# Altium schematic sheet Jetson_power.SchDoc
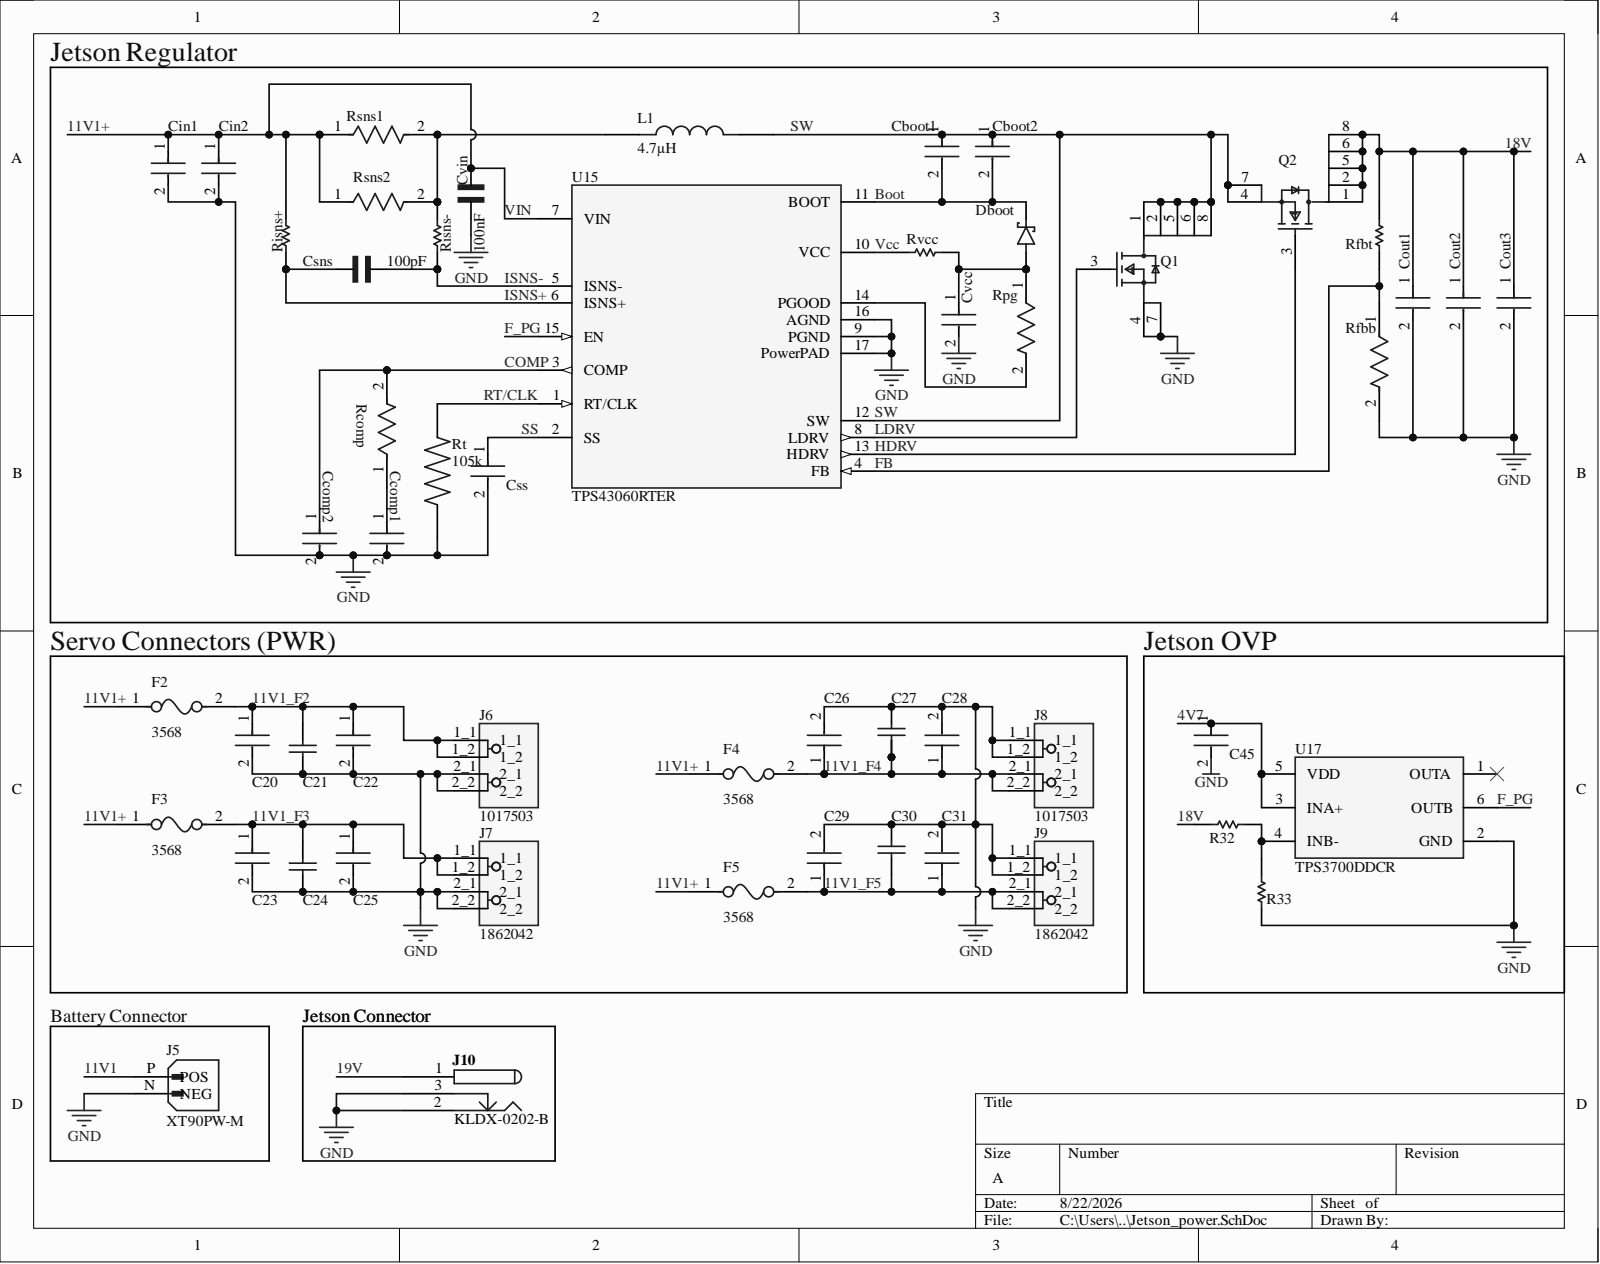
[diagram p0: Jetson_power.SchDoc]

## Parts
C20  CL32A106KBJNNNE  [FP-CL32-IPC_B]  (value not drawn)
C21  C0805C105K5RACTU  [CAPC2013X140X50ML20T25]  (value not drawn)
C22  CC0805KRX7R9BB104  [FP-CC0805-0_85-MFG]  (value not drawn)
C23  CL32A106KBJNNNE  [FP-CL32-IPC_B]  (value not drawn)
C24  C0805C105K5RACTU  [CAPC2013X140X50ML20T25]  (value not drawn)
C25  CC0805KRX7R9BB104  [FP-CC0805-0_85-MFG]  (value not drawn)
C26  CL32A106KBJNNNE  [FP-CL32-IPC_B]  (value not drawn)
C27  C0805C105K5RACTU  [CAPC2013X140X50ML20T25]  (value not drawn)
C28  CC0805KRX7R9BB104  [FP-CC0805-0_85-MFG]  (value not drawn)
C29  CL32A106KBJNNNE  [FP-CL32-IPC_B]  (value not drawn)
C30  C0805C105K5RACTU  [CAPC2013X140X50ML20T25]  (value not drawn)
C31  CC0805KRX7R9BB104  [FP-CC0805-0_85-MFG]  (value not drawn)
C45  GRM155R71H104KE14D  [FP-GRM155-0_05-IPC_B]  (value not drawn)
Cboot1  CC0805KRX7R9BB104  [FP-CC0805-0_85-MFG]  (value not drawn)
Cboot2  CC0805KRX7R9BB104  [FP-CC0805-0_85-MFG]  (value not drawn)
Ccomp1  CGA4C2C0G1H822J060AA  [FP-CGA4J-IPC_A]  (value not drawn)
Ccomp2  GRM1555C1H391JA01J  [FP-GRM155-0_05-IPC_A]  (value not drawn)
Cin1  CL32A106KBJNNNE  [FP-CL32-IPC_B]  (value not drawn)
Cin2  CL32A106KBJNNNE  [FP-CL32-IPC_B]  (value not drawn)
Cout1  CL32B106KBJNNWE  [FP-CL32-IPC_B]  (value not drawn)
Cout2  CL32B106KBJNNWE  [FP-CL32-IPC_B]  (value not drawn)
Cout3  CL32B106KBJNNWE  [FP-CL32-IPC_B]  (value not drawn)
Csns  value 100pF  [CAPC1608X90N]  (hidden comment: GRM1885C1H101JA01D)
Css  GRM155R71H104KE14D  [FP-GRM155-0_05-IPC_B]  (value not drawn)
Cvcc  GRM188R61C106MA73D  [FP-GRM188-0_2-IPC_A]  (value not drawn)
Cvin  value 100nF  [CAPC1005X55N]  (hidden comment: GRM155R71C104KA88D)
Dboot  XBS053V15R-G  [DIO_XBS053V15R-G]  (value not drawn)
F2  3568  [FP-3568-MFG]
F3  3568  [FP-3568-MFG]
F4  3568  [FP-3568-MFG]
F5  3568  [FP-3568-MFG]
J5  XT90PW-M  [AMASS_XT90PW-M - duplicate]
J6  1017503  [PHOENIX_1017503]
J7  1862042  [PHOENIX_1862042]
J8  1017503  [PHOENIX_1017503]
J9  1862042  [PHOENIX_1862042]
J10  KLDX-0202-B  [KYCN-KLDX-0202-B_V]
L1  value 4.7µH  [IND_XAL6060-472MEB]  (hidden comment: XAL6060-472MEB)
Q1  CSD17571Q2  [FP-DQK0006C-MFG]  (value not drawn)
Q2  CSD17571Q2  [FP-DQK0006C-MFG]  (value not drawn)
R32  CRCW0402475KFKED  [RESC1005X40X25LL05T05]  (value not drawn)
R33  CRCW040210K0FKEDHP  [RESC1005X40X25ML05T10]  (value not drawn)
Rcomp  CRCW04025K36FKED  [FP-CRCW0402-e3-IPC_B]  (value not drawn)
Rfbb  CRCW040211K0FKED  [FP-CRCW0402-e3-IPC_A]  (value not drawn)
Rfbt  CRCW0402150KFKED  [RESC1005X40X25NL05T05]  (value not drawn)
Risns+  CRCW040210R0FKED  [RESC1005X40X25NL05T05]  (value not drawn)
Risns-  CRCW040210R0FKED  [RESC1005X40X25NL05T05]  (value not drawn)
Rpg  CRCW0402100KFKED  [FP-CRCW0402-e3-IPC_C]  (value not drawn)
Rsns1  PRL1632-R010-F-T1  [FP-PRL1632-MFG]  (value not drawn)
Rsns2  PRL1632-R010-F-T1  [FP-PRL1632-MFG]  (value not drawn)
Rt  value 105k  [RESC0603X26N]  (hidden comment: RC0201FR-07105KL)
Rvcc  CRCW04022R00FKED  [RESC1005X40X25ML05T05]  (value not drawn)
U15  TPS43060RTER  [FP-RTE0016E-IPC_B]
U17  TPS3700DDCR  [FP-DDC0006A-IPC_A]

## Nets
GND: C20.2 C21.1 C22.2 C23.2 C24.1 C25.2 C26.2 C27.1 C28.2 C29.2 C30.1 C31.2 C45.2 Ccomp1.2 Ccomp2.2 Cin1.2 Cin2.2 Cout1.2 Cout2.2 Cout3.2 Css.2 Cvcc.2 Cvin.1 J5.N J6.2_1 J6.2_2 J7.2_1 J7.2_2 J8.1_1 J8.1_2 J9.1_1 J9.1_2 J10.2 J10.3 Q1.4 Q1.7 R33.2 Rfbb.2 Rt.2 U15.9(PGND) U15.16(AGND) U15.17(PowerPAD) U17.2(GND)
4V7: C45.1 U17.3(INA+) U17.5(VDD)
11V1: J5.P
11V1+: Cin1.1 Cin2.1 Cvin.2 F2.1 F3.1 F4.1 F5.1 Risns+.1 Rsns1.1 Rsns2.1 U15.7(VIN)
11V1_F2: C20.1 C21.2 C22.1 F2.2 J6.1_1 J6.1_2
11V1_F3: C23.1 C24.2 C25.1 F3.2 J7.1_1 J7.1_2
11V1_F4: C26.1 C27.2 C28.1 F4.2 J8.2_1 J8.2_2
11V1_F5: C29.1 C30.2 C31.1 F5.2 J9.2_1 J9.2_2
18V: Cout1.1 Cout2.1 Cout3.1 Q2.1 Q2.2 Q2.5 Q2.6 Q2.8 R32.1 Rfbt.2
19V: J10.1
Boot: Cboot1.2 Cboot2.2 Dboot.C U15.11(BOOT)
COMP: Ccomp2.1 Rcomp.2 U15.3(COMP)
F_PG: U15.15(EN) U17.6(OUTB)
FB: Rfbb.1 Rfbt.1 U15.4(FB)
HDRV: Q2.3 U15.13(HDRV)
ISNS+: Csns.1 Risns+.2 U15.6(ISNS+)
ISNS-: Csns.2 Risns-.1 U15.5(ISNS-)
LDRV: Q1.3 U15.8(LDRV)
RT/CLK: Rt.1 U15.1(RT/CLK)
SS: Css.1 U15.2(SS)
SW: Cboot1.1 Cboot2.1 L1.2 Q1.1 Q1.2 Q1.5 Q1.6 Q1.8 Q2.4 Q2.7 U15.12(SW)
Vcc: Rvcc.1 U15.10(VCC)
NetCcomp1_1: Ccomp1.1 Rcomp.1
NetCvcc_1: Cvcc.1 Dboot.A Rpg.1 Rvcc.2
NetL1_1: L1.1 Risns-.2 Rsns1.2 Rsns2.2
NetR32_2: R32.2 R33.1 U17.4(INB-)
NetRpg_2: Rpg.2 U15.14(PGOOD)

Not connected: U17 1(OUTA)

## Library data of the parts
C20 C23 C26 C29 Cin1 Cin2 = CMP-13271-001337-1: Cap Ceramic 10uF 50V X5R ±10% SMD 1210 +85°C Embossed T/R; Capacitance: 10uF; Case Code (Imperial): 1210; Case Code (Metric): 3225; Case/Package: 1210; Component Kind: Standard; Depth: 2.5mm; Dielectric: X5R; Lead Free: Lead Free; Length: 0.126inch; Library Name: Altium Content Vault; Max Operating Temperature: 85°C; Min Operating Temperature: -55°C; Mount: Surface Mount; Packaging: Tape and Reel; Thickness: 0.106inch; Tolerance: 10%; Voltage Rating: 50V; Voltage Rating (DC): 50V; Width: 0.098inch
C21 C24 C27 C30 = CMP-2007-03236-1: Manufacturer: Kemet; Component Kind: Standard; Library Name: Altium Content Vault; Part Number: C0805C105K5RACTU
C22 C25 C28 C31 = CMP-2100-03606-3: CAP CER 0.1UF 50V X7R 0805; Manufacturer: Yageo; Capacitance: 100 nF; Material: Ceramic; Tolerance: 0.1; Value: 100 nF; Automotive: No; Category: Cap; Component Kind: Standard; ComponentLink2Description: Datasheet; ComponentLink2URL: http://www.yageo.com/documents/recent/UPY-GPHC_Y5V_6.3V-to-50V_8.pdf; Device Class L1: Passive Components; Device Class L2: Capacitors; Device Class L3: Ceramic Capacitors; Digikey Description: CAP CER 0.1UF 50V X7R 0805; Footprint Url: http://www.yageo.com/exep/pages/download/literatures/UPY-C_GEN_24.pdf; Height: 0.95mm; Ipc Land Pattern Name: CAPC200125X85; Lead Free: Yes; Library Name: Altium Content Vault; Manufacturer Part Number: CC0805KRX7R9BB104; Mouser Description: Multilayer Ceramic Capacitors MLCC - SMD/SMT 100nF 50V X7R 10%; Octopart: https://octopart.com/cc0805krx7r9bb104-yageo-192993; Package: CC0805-0.85; RoHS: TRUE; Temperature: -30°C to +85°C; Temperature Characteristic: X7R; Temperature Range High: +85°C; Temperature Range Low: -30°C; Voltage Rating: 50 V
C45 Css = CMP-2008-04639-2: Chip Multilayer Ceramic Capacitors for General Purpose, 0402, 0.10uF, X7R, 15%, 10%, 50V; Capacitance: 100nF; Dissipation Factor: 0.025%; Case Code (Imperial): 0402; Case Code (Metric): 1005; Case/Package: 0402; Component Kind: Standard; Depth: 0.5mm; Dielectric: X7R; Dielectric Material: Ceramic; Height: 0.5mm; Lead Free: Lead Free; Length: 1mm; Library Name: Altium Content Vault; Material: Ceramic; Max Operating Temperature: 125°C; Min Operating Temperature: -55°C; Mount: Surface Mount; Package Quantity: 10000; Packaging: Tape and Reel; REACH SVHC: No SVHC; Resistor Type: Ceramic; Termination: SMD/SMT; Thickness: 0.022inch; Tolerance: 10%; Voltage: 50V; Voltage Rating: 50V; Voltage Rating (DC): 50V; Weight: 0.000212oz; Width: 0.5mm
Cboot1 Cboot2 = CMP-2100-03606-3: CAP CER 0.1UF 50V X7R 0805; Manufacturer: Yageo; Capacitance: 100 nF; Tolerance: 0.1; Value: 100 nF; Automotive: No; Category: Cap; Component Kind: Standard; ComponentLink2Description: Datasheet; ComponentLink2URL: http://www.yageo.com/documents/recent/UPY-GPHC_Y5V_6.3V-to-50V_8.pdf; Device Class L1: Passive Components; Device Class L2: Capacitors; Device Class L3: Ceramic Capacitors; Digikey Description: CAP CER 0.1UF 50V X7R 0805; Footprint Url: http://www.yageo.com/exep/pages/download/literatures/UPY-C_GEN_24.pdf; Height: 0.95mm; Ipc Land Pattern Name: CAPC200125X85; Lead Free: Yes; Library Name: Altium Content Vault; Manufacturer Part Number: CC0805KRX7R9BB104; Material: Ceramic; Mouser Description: Multilayer Ceramic Capacitors MLCC - SMD/SMT 100nF 50V X7R 10%; Octopart: https://octopart.com/cc0805krx7r9bb104-yageo-192993; Package: CC0805-0.85; RoHS: TRUE; Temperature: -30°C to +85°C; Temperature Characteristic: X7R; Temperature Range High: +85°C; Temperature Range Low: -30°C; Voltage Rating: 50 V
Ccomp1 = CMP-08246-000542-1: Cap Ceramic 8.2nF 50V C0G 5% Pad SMD 0805 +125°C Automotive T/R; Capacitance: 8.2nF; Case Code (Imperial): 0805; Case Code (Metric): 2012; Case/Package: 0805; Component Kind: Standard; Composition: Ceramic; Depth: 1.25mm; Dielectric: C0G; Height: 0.6mm; Length: 2mm; Library Name: Altium Content Vault; Max Operating Temperature: 125°C; Min Operating Temperature: -55°C; Mount: Surface Mount; Package Quantity: 1; Packaging: Tape and Reel; Ratings: AEC-Q200; REACH SVHC: No SVHC; Termination: SMD/SMT; Thickness: 0.028inch; Tolerance: 5%; Voltage Rating: 50V; Voltage Rating (DC): 50V; Weight: 0.000194oz; Width: 0.049inch
Ccomp2 = CMP-2008-04006-2: Chip Multilayer Ceramic Capacitors for General Purpose, 0402, 390pF, C0G, 30ppm/°C, 5%, 50V; Capacitance: 390pF; Case Code (Imperial): 0402; Case Code (Metric): 1005; Case/Package: 0402; Component Kind: Standard; Depth: 0.5mm; Dielectric: C0G; Height: 0.5mm; Length: 1mm; Library Name: Altium Content Vault; Max Operating Temperature: 125°C; Min Operating Temperature: -55°C; Mount: Surface Mount; Package Quantity: 1; Packaging: Tape and Reel; Radiation Hardening: No; Termination: SMD/SMT; Thickness: 0.022inch; Tolerance: 5%; Voltage: 50V; Voltage Rating: 50V; Voltage Rating (DC): 50V; Width: 0.02inch
Cout1-Cout3 = CMP-13271-004028-1: Cap Ceramic 10uF 50V X7R ±10% SMD 1210 +125°C Embossed T/R; Capacitance: 10uF; Case Code (Imperial): 1210; Case Code (Metric): 3225; Case/Package: 1210; Component Kind: Standard; Dielectric: X7R; Lead Free: Lead Free; Length: 0.126inch; Library Name: Altium Content Vault; Max Operating Temperature: 125°C; Min Operating Temperature: -55°C; Mount: Surface Mount; Package Quantity: 1; Packaging: Tape and Reel; Thickness: 0.106inch; Tolerance: 10%; Voltage Rating: 50V; Width: 0.098inch
Csns = CMP-001-00083-1: SMD Capacitor C0G(EIA) with Capacitance: 100pF Tol. 5%. Rated Voltage: 50Vdc; MF: Murata Electronics; Case/Package: 1608 Murata; Check_prices: https://www.snapeda.com/parts/GRM1885C1H101JA01D/Murata+Electronics+North+America/view-part/?ref=eda; Component Kind: Standard; Component Type: Standard; Design Item ID: GRM1885C1H101JA01D; MP: GRM1885C1H101JA01D; Pins: 2; SnapEDA_Link: https://www.snapeda.com/parts/GRM1885C1H101JA01D/Murata+Electronics+North+America/view-part/?ref=snap; Source: GRM1885C1H101JA01D.SchLib; Library Name: Bryan Heddle
Cvcc = CMP-2006-02814-2: Chip Multilayer Ceramic Capacitors for General Purpose, 0603, 10uF, X5R, 15%, 20%, 16V; Capacitance: 10uF; Case Code (Imperial): 0603; Case Code (Metric): 1608; Case/Package: 0603; Component Kind: Standard; Depth: 0.8mm; Dielectric: X5R; Height: 0.8mm; Lead Free: Lead Free; Length: 1.6mm; Library Name: Altium Content Vault; Max Operating Temperature: 85°C; Min Operating Temperature: -55°C; Mount: Surface Mount; Package Quantity: 4000; Packaging: Tape and Reel; REACH SVHC: No SVHC; Thickness: 0.031inch; Tolerance: 20%; Voltage: 16V; Voltage Rating: 16V; Voltage Rating (DC): 16V; Width: 0.031inch
Cvin = CMP-001-00084-1: SMD Capacitor X7R(EIA) with Capacitance: 0.10uF Tol. 10%. Rated Voltage: 16Vdc; MF: Murata; Case/Package: 1005-2 Murata; Check_prices: https://www.snapeda.com/parts/GRM155R71C104KA88D/Murata/view-part/?ref=eda; Component Kind: Standard; Component Type: Standard; Design Item ID: GRM155R71C104KA88D; MP: GRM155R71C104KA88D; Pins: 2; SnapEDA_Link: https://www.snapeda.com/parts/GRM155R71C104KA88D/Murata/view-part/?ref=snap; Source: GRM155R71C104KA88D.SchLib; Library Name: Bryan Heddle
Dboot = CMP-004-00031-1: Diode Schottky 20V 500mA Surface Mount SOD-523; MF: Torex; Case/Package: SOD-523 Torex; Component Kind: Standard; Component Type: Standard; Design Item ID: XBS053V15R-G; Digi-Key_Part_Number: XBS053V15R-G-ND; Digi-Key_Purchase_URL: https://www.digikey.com/product-detail/en/torex-semiconductor-ltd/XBS053V15R-G/XBS053V15R-G-ND/2765022?utm_source=snapeda&utm_medium=aggregator&utm_campaign=symbol; MP: XBS053V15R-G; Pins: 2; SnapEDA_Link: https://www.snapeda.com/parts/XBS053V15R-G/Torex/view-part/808826/?ref=snap; Source: SnapEDAsch.SchLib; Library Name: Bryan Heddle
F2-F5 = CMP-2000-05464-2: NexarPartId: 8116161; Approvals: UL; Body Material: Nylon; Color: Black; Current Rating: 20A; Illumination Type: Illuminated; Material: Brass, Nylon, Tin; Number of Circuits: 1; Voltage: 500V; Component Kind: Standard; Contact Material: Brass; Contact Plating: Tin; Depth: 6.73mm; Flammability Rating: UL94 V-0; Fuse Current: 20A; Lead Free: Lead Free; Length: 16mm; Library Name: Altium Content Vault; Max Operating Temperature: 145°C; Min Operating Temperature: -50°C; Mount: PCB, Vertical; Number of Poles: 1; Orientation: Vertical; REACH SVHC: No SVHC; RoHS: Compliant; Termination: PC Pin; Voltage Rating (AC): 500V
J5 = XT90PW-M: Socket; DC supply; XT90; male; PIN: 2; on PCBs; THT; Colour: yellow; MF: AMASS; Check_prices: https://www.snapeda.com/parts/XT90PW-M/AMASS/view-part/?ref=eda; Component Kind: Standard; Library Name: AI_Project_Library.SchLib; MP: XT90PW-M; Package: Package; SnapEDA_Link: https://www.snapeda.com/parts/XT90PW-M/AMASS/view-part/?ref=snap
J6 J8 = CMP-033-000000-2: Availability: Unavailable; Component Kind: Standard; Component Type: Standard; Design Item ID: 1017503; MP: 1017503; Package: None; Pin Count: 4; Price: None; Source: 1017503.SchLib; Library Name: Bryan Heddle
J7 J9 = CMP-031-000000-2: MF: {'manufacturer': u'Phoenix Contact'}; Availability: Unavailable; Component Kind: Standard; Component Type: Standard; Design Item ID: 1862042; MP: 1862042; Package: None; Pin Count: 4; Price: None; Source: 1862042.SchLib; Library Name: Bryan Heddle
J10 = CMP-0256-00001-1: 3 Conductor Power Jack, 2 break 2 aux contacts; Component Kind: Standard; ComponentLink1Description: Manufacturer URL; ComponentLink1URL: http://kycon.com/; ComponentLink2Description: Datasheet; ComponentLink2URL: http://anacapa.kycon.com/Catalog_PDF/KLDX_0202.pdf; Contact Finish: Brass; Library Name: Altium Content Vault; Mounting Technology: Through Hole; PackageDescription: Jack, 9 x 14.5 mm Body, 2.5 mm Center Pin Diameter; PackageReference: KLDX-0202-B; PartNumber: KLDX-0202-B
L1 = CMP-006-00021-1: Power inductor, shielded, 20% tol, SMT, RoHS, halogen-f; MF: Coilcraft; Case/Package: None; Check_prices: https://www.snapeda.com/parts/XAL6060-472MEB/Coilcraft/view-part/?ref=eda; Component Kind: Standard; Component Type: Standard; Design Item ID: XAL6060-472MEB; MP: XAL6060-472MEB; Pins: 2; SnapEDA_Link: https://www.snapeda.com/parts/XAL6060-472MEB/Coilcraft/view-part/?ref=snap; Source: XAL6060-472MEB.SchLib; Library Name: Bryan Heddle
Q1 Q2 = CMP-04962-000062-1: MOSFET N-CH 30V 22A 6SON; Drain to Source Resistance: 29mR; Element Configuration: Single; Fall Time: 26ns; Gate to Source Voltage (Vgs): 20V; Input Capacitance: 468pF; Max Power Dissipation: 2.5W; Number of Channels: 1; Rise Time: 19ns; Turn-Off Delay Time: 8ns; Turn-On Delay Time: 5.3ns; Component Kind: Standard; Contact Plating: Tin; Continuous Drain Current (ID): 22A; Drain to Source Breakdown Voltage: 30V; Drain to Source Voltage (Vdss): 30V; Lead Free: Lead Free; Library Name: Altium Content Vault; Max Operating Temperature: 150°C; Min Operating Temperature: -55°C; Mount: Surface Mount; Number of Elements: 1; Number of Pins: 6; Package Quantity: 3000; Packaging: Tape and Reel; Power Dissipation: 2.5W; Rds On Max: 29mR; RoHS Compliant: Yes
R32 = CMP-2002-00024-1: Manufacturer: Vishay Dale; Component Kind: Standard; Library Name: Altium Content Vault; Part Number: CRCW0402475KFKED
R33 = CMP-2002-03043-1: Manufacturer: Vishay Dale; Component Kind: Standard; Library Name: Altium Content Vault; Part Number: CRCW040210K0FKEDHP
Rcomp = CMP-1011-00593-3: RES Thick Film, 5.36kΩ, 1%, 0.063W, 100ppm/°C, 0402; Max Power Dissipation: 63mW; Resistance: 5.36kR; Voltage Rating: 50V; Case Code (Imperial): 0402; Case Code (Metric): 1005; Case/Package: 0402; Component Kind: Standard; Construction: Rectangular; Contact Plating: Tin; Depth: 0.5mm; Features: Automotive AEC-Q200; Height: 0.016inch; Lead Free: Lead Free; Length: 1mm; Library Name: Altium Content Vault; Max Operating Temperature: 155°C; Military Standard: Not; Min Operating Temperature: -55°C; Number of Pins: 2; Number of Terminations: 2; Package Quantity: 10; Packaging: Tape and Reel; Power Rating: 63mW; Radiation Hardening: No; Resistance Tolerance: 1%; Resistor Type: Thick Film; RoHS Compliant: Yes; Series: CRCW; Temperature Coefficient: 100ppm/°C; Tolerance: 1%; Voltage Rating (DC): 50V; Weight: 2.3E-05oz; Width: 0.5mm
Rfbb = CMP-2002-08157-2: RES Thick Film, 11kΩ, 1%, 0.063W, 100ppm/°C, 0402; Max Power Dissipation: 63mW; Resistance: 11kR; Voltage Rating: 50V; Case Code (Imperial): 0402; Case Code (Metric): 1005; Case/Package: 0402; Component Kind: Standard; Composition: Thick Film; Contact Plating: Tin; Depth: 0.5mm; Features: Automotive AEC-Q200; Height: 0.35mm; Lead Free: Lead Free; Length: 1mm; Library Name: Altium Content Vault; Max Operating Temperature: 155°C; Military Standard: Not; Min Operating Temperature: -55°C; Number of Pins: 2; Number of Terminations: 2; Package Quantity: 10; Packaging: Tape and Reel; Power Rating: 63mW; Radiation Hardening: No; Temperature Coefficient: 100ppm/°C; Termination: SMD/SMT; Tolerance: 1%; Voltage Rating (DC): 50V; Weight: 2.3E-05oz; Width: 0.02inch
Rfbt = CMP-2002-08212-1: Manufacturer: Vishay Dale; Component Kind: Standard; Library Name: Altium Content Vault; Part Number: CRCW0402150KFKED
Risns+ Risns- = CMP-2002-08143-1: Manufacturer: Vishay Dale; Component Kind: Standard; Library Name: Altium Content Vault; Part Number: CRCW040210R0FKED
Rpg = CMP-2002-08123-3: RES Thick Film, 100kΩ, 1%, 0.063W, 100ppm/°C, 0402; Max Power Dissipation: 63mW; Resistance: 100kR; Voltage Rating: 50V; Case Code (Imperial): 0402; Case Code (Metric): 1005; Case/Package: 0402; Component Kind: Standard; Composition: Thick Film; Contact Plating: Tin; Depth: 0.5mm; Features: Automotive AEC-Q200; Height: 0.35mm; Lead Free: Lead Free; Length: 1mm; Library Name: Altium Content Vault; Max Operating Temperature: 155°C; Military Standard: Not; Min Operating Temperature: -55°C; Mount: Surface Mount; Number of Pins: 2; Number of Terminations: 2; Package Quantity: 10000; Packaging: Tape and Reel; Power Rating: 63mW; Radiation Hardening: No; Temperature Coefficient: 100ppm/°C; Termination: SMD/SMT; Tolerance: 1%; Voltage Rating (DC): 50V; Weight: 2.3E-05oz; Width: 0.02inch
Rsns1 Rsns2 = CMP-2003-04764-2: 10 mOhms ±1% 1W Chip Resistor Wide 0612 (1632 Metric), Current Sense Thin Film; Resistance: 10mR; Temperature Coefficient: 200ppm/°C; Case Code (Imperial): 1206; Case Code (Metric): 3216; Case/Package: 1206; Component Kind: Standard; Height: 0.5mm; Lead Free: Lead Free; Length: 3.2mm; Library Name: Altium Content Vault; Max Operating Temperature: 125°C; Max Power Dissipation: 1W; Min Operating Temperature: -55°C; Number of Terminations: 2; Package Quantity: 1000; Packaging: Cut Tape; Power Rating: 1W; Resistor Type: Thin Film; RoHS Compliant: Yes; Technology: THIN FILM; Termination: SMD/SMT; Tolerance: 1%; Weight: 0.000466oz; Width: 1.6mm
Rt = CMP-009-00177-1: 105 kOhms Â±1% 0.05W, 1/20W Chip Resistor 0201 (0603 Metric) Moisture Resistant Thick Film; MF: Yageo; Case/Package: SMD-2 Yageo; Check_prices: https://www.snapeda.com/parts/RC0201FR-07105KL/Yageo/view-part/?ref=eda; Component Kind: Standard; Component Type: Standard; Design Item ID: RC0201FR-07105KL; MP: RC0201FR-07105KL; Pins: 2; SnapEDA_Link: https://www.snapeda.com/parts/RC0201FR-07105KL/Yageo/view-part/?ref=snap; Source: RC0201FR-07105KL.SchLib; Library Name: Bryan Heddle
Rvcc = CMP-2002-08486-1: Manufacturer: Vishay Dale; Component Kind: Standard; Library Name: Altium Content Vault; Part Number: CRCW04022R00FKED
U15 = CMP-04918-000522-1: IC REG CTRLR BOOST 16WQFN; Clock Sync: No; Duty Cycle: 95%; Max Duty Cycle: 50%; Max Frequency: 1MHz; Number of Output Phases: 1; Operating Supply Voltage: 7.5V; Output Configuration: Positive; Output Current: 2A; Output Voltage: 58V; Quiescent Current: 600uA; Synchronous Rectifier: Yes; Component Kind: Standard; Contact Plating: Gold; Lead Free: Lead Free; Library Name: Altium Content Vault; Max Operating Temperature: 150°C; Min Operating Temperature: -40°C; Mount: Surface Mount; Number of Outputs: 1; Number of Pins: 16; Package Quantity: 3000; Packaging: Tape and Reel; Radiation Hardening: No; RoHS Compliant: Yes; Switching Frequency: 1MHz; Topology: Boost
U17 = CMP-1708-00001-5: IC COMP WINDOW W/REF 6SOT; Hysteresis: 5.5mV; Max Supply Voltage: 18V; Min Supply Voltage: 1.8V; Nominal Supply Current: 7uA; Number of Channels: 1; Number of Elements: 2; Operating Supply Current: 5.5uA; Propagation Delay: 29us; Quiescent Current: 11uA; Undervoltage Threshold: 1.7V; Watchdog Timer: No; Case/Package: SOT; Component Kind: Standard; Lead Free: Lead Free; Library Name: Altium Content Vault; Max Operating Temperature: 125°C; Min Operating Temperature: -40°C; Mount: Surface Mount; Number of Pins: 6; Number of Voltages Monitored: 2; Package Quantity: 3000; Packaging: Tape and Reel; Radiation Hardening: No; REACH SVHC: No SVHC
